# Altium schematic sheet SSD202.SchDoc(SSD202)
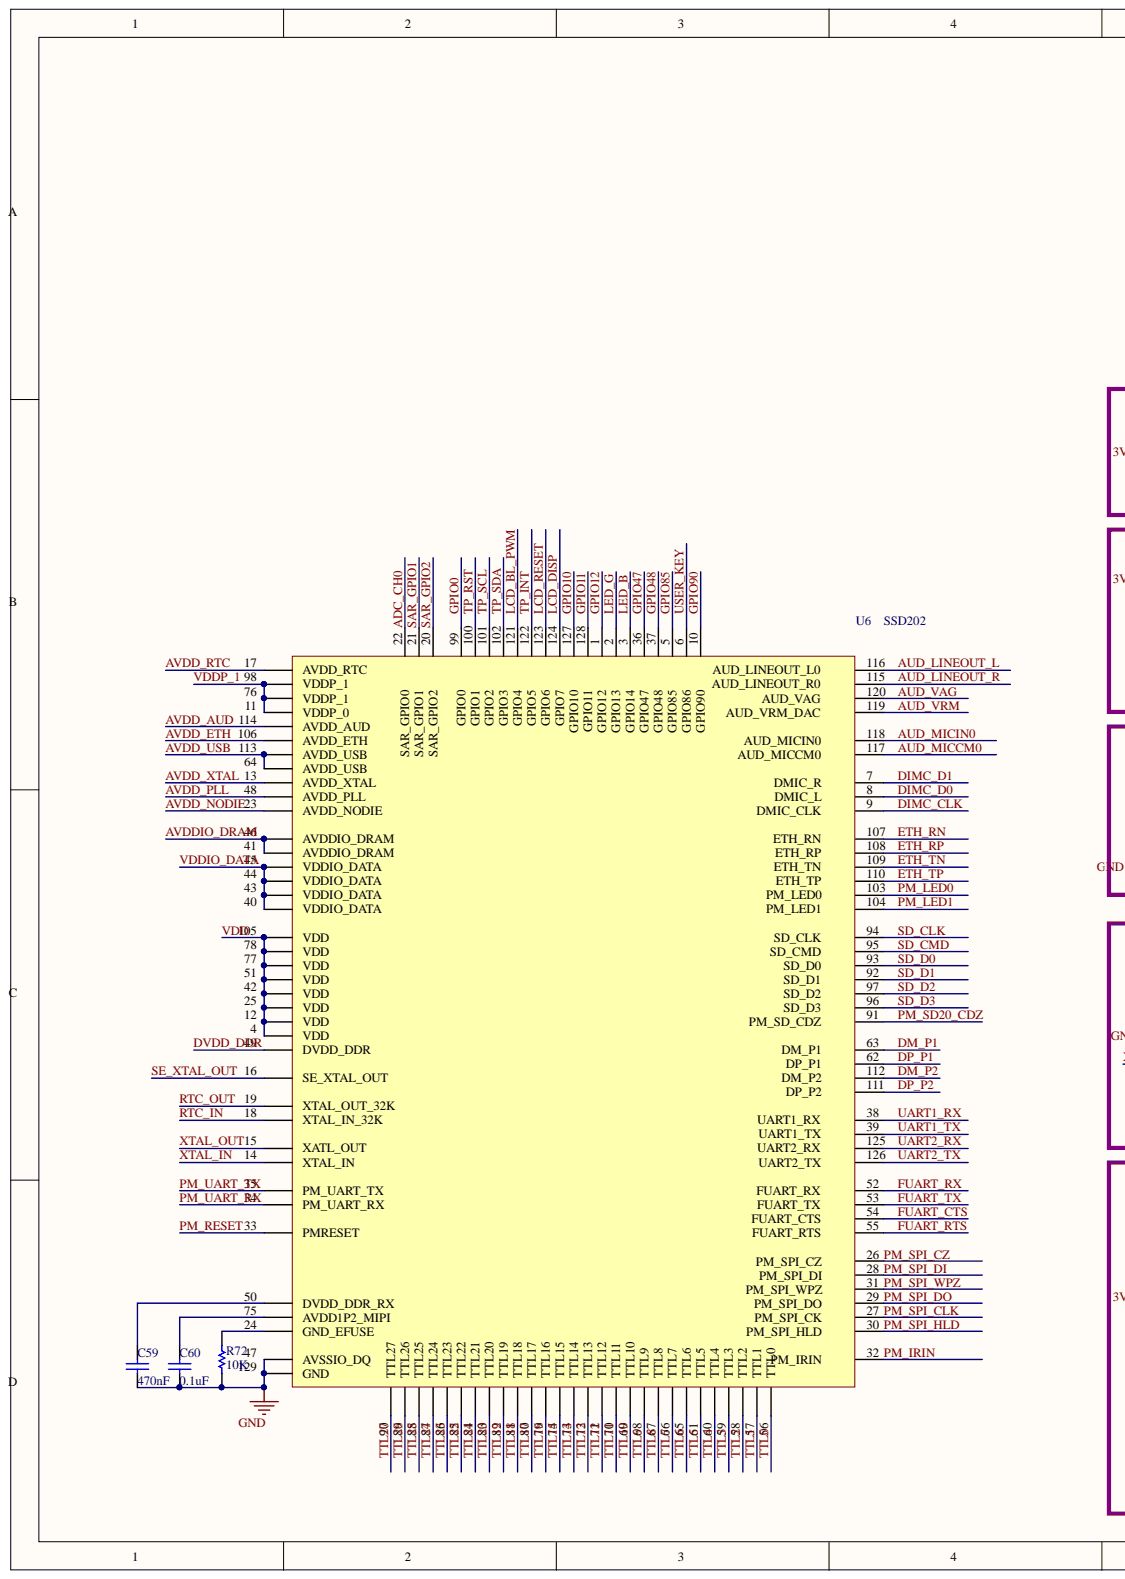
[diagram p0: SSD202.SchDoc, left]
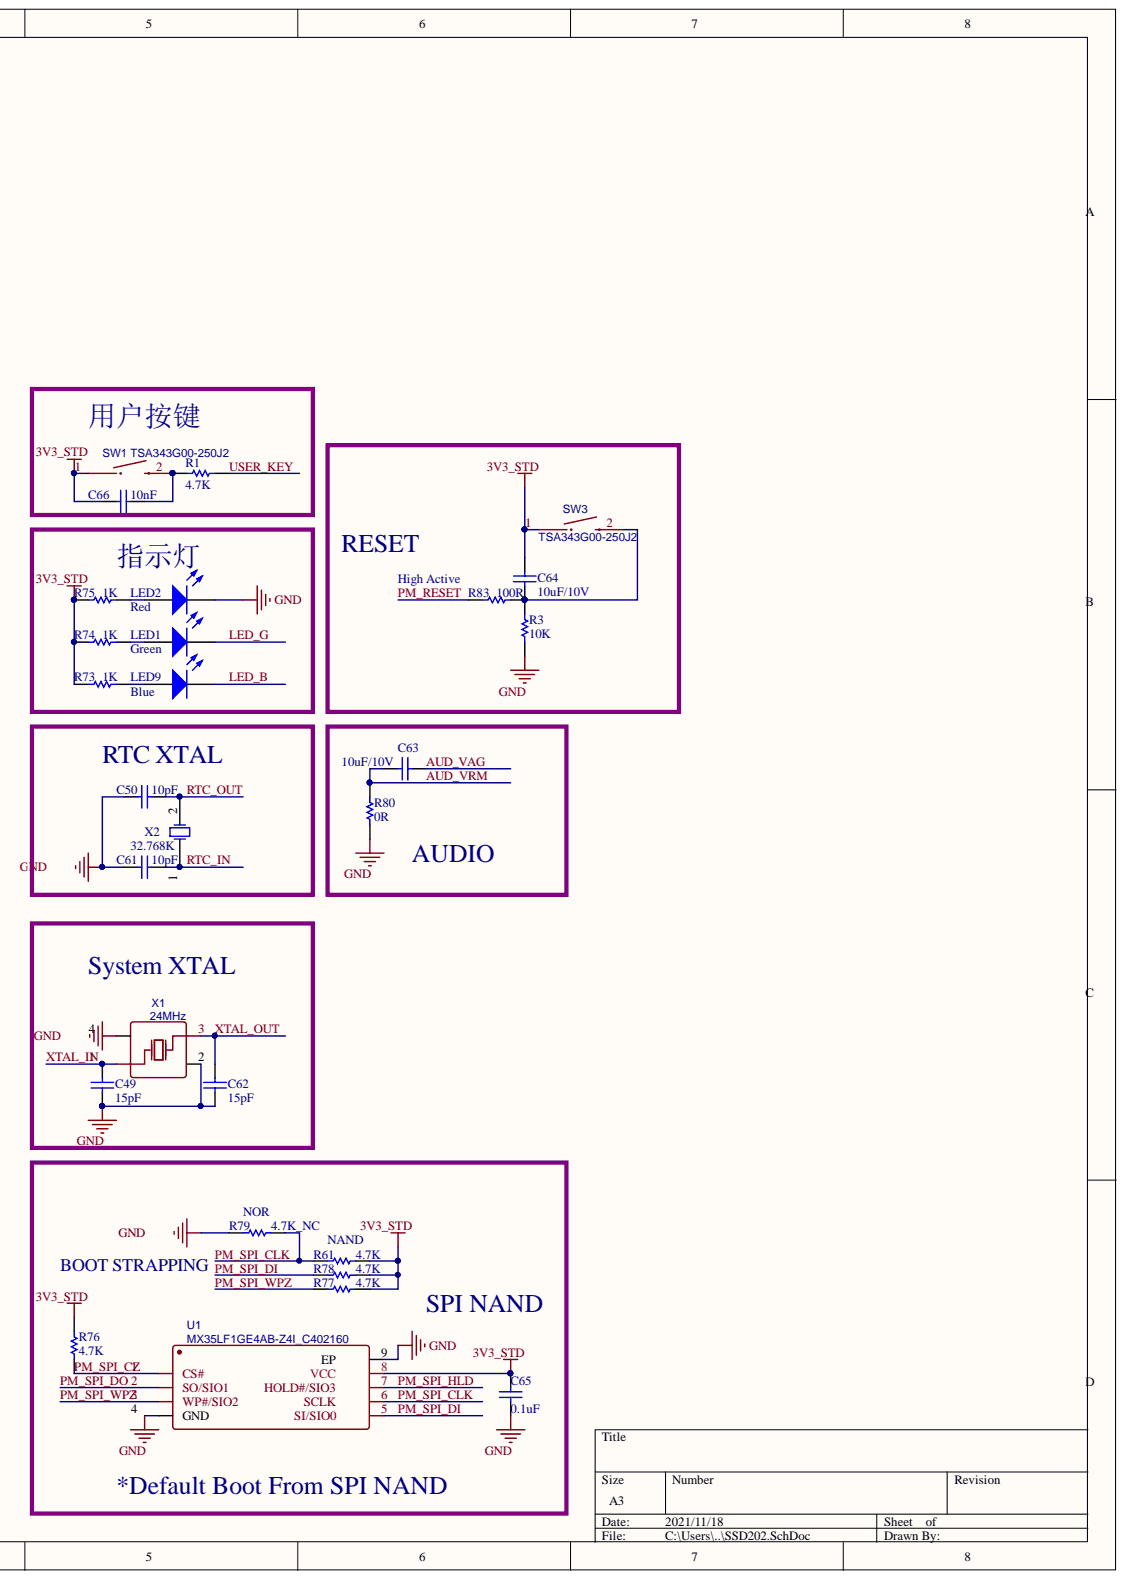
[diagram p1: SSD202.SchDoc, right]

## Parts
C49  15pF  [0402]
C50  10pF  [0402]
C59  470nF  [0402]
C60  0.1uF  [0402]
C61  10pF  [0402]
C62  15pF  [0402]
C63  10uF/10V  [0603]
C64  10uF/10V  [0603]
C65  0.1uF  [0402]
C66  10nF  [0402]
LED1  Green  [LED0603]
LED2  Red  [LED0603]
LED9  Blue  [LED0603]
R1  4.7K  [0402]
R3  10K  [0402]
R61  4.7K  [0402]
R72  10K  [0402]
R73  1K  [0402]
R74  1K  [0402]
R75  1K  [0402]
R76  4.7K  [0402]
R77  4.7K  [0402]
R78  4.7K  [0402]
R79  4.7K_NC  [0402]
R80  0R  [0402]
R83  100R  [0402]
SW1  TSA343G00-250J2  [SW-SMD_L4.0-W2.9-LS5.0]
SW3  TSA343G00-250J2  [SW-SMD_L4.0-W2.9-LS5.0]
U1  MX35LF1GE4AB-Z4I_C402160  [WSON-8_L6.0-W8.0-P1.27-BL-EP]
U6  SSD202  [SSD202_QFN128]
X1  24MHz  [OSC-SMD_4P-L3.2-W2.5-BL]
X2  XTAL  value 32.768K  [SMD3215]

## Nets
3V3_STD: C64.2 C65.2 C66.1 R61.2 R73.2 R74.2 R75.2 R76.1 R77.2 R78.2 SW1.1 SW3.1 U1.8
GND: C49.2 C50.2 C59.2 C60.2 C61.2 C62.2 C65.1 LED2.2 R3.2 R72.2 R79.1 R80.2 U1.4(GND) U1.9 U6.47(AVSSIO_DQ) U6.129(GND) X1.2 X1.4
ADC_CH0: U6.22
AUD_LINEOUT_L: U6.116
AUD_LINEOUT_R: U6.115
AUD_MICCM0: U6.117
AUD_MICIN0: U6.118
AUD_VAG: C63.2 U6.120
AUD_VRM: C63.1 R80.1 U6.119
AVDD_AUD: U6.114(AVDD_AUD)
AVDD_ETH: U6.106(AVDD_ETH)
AVDD_NODIE: U6.23(AVDD_NODIE)
AVDD_PLL: U6.48(AVDD_PLL)
AVDD_RTC: U6.17(AVDD_RTC)
AVDD_USB: U6.64(AVDD_USB) U6.113(AVDD_USB)
AVDD_XTAL: U6.13(AVDD_XTAL)
AVDDIO_DRAM: U6.41(AVDDIO_DRAM) U6.46(AVDDIO_DRAM)
DIMC_CLK: U6.9
DIMC_D0: U6.8
DIMC_D1: U6.7
DM_P1: U6.63
DM_P2: U6.112
DP_P1: U6.62
DP_P2: U6.111
DVDD_DDR: U6.49(DVDD_DDR)
ETH_RN: U6.107
ETH_RP: U6.108
ETH_TN: U6.109
ETH_TP: U6.110
FUART_CTS: U6.54
FUART_RTS: U6.55
FUART_RX: U6.52
FUART_TX: U6.53
GPIO0: U6.99
GPIO10: U6.127
GPIO11: U6.128
GPIO12: U6.1
GPIO47: U6.36
GPIO48: U6.37
GPIO85: U6.5
GPIO90: U6.10
LCD_BL_PWM: U6.121
LCD_DISP: U6.124
LCD_RESET: U6.123
LED_B: LED9.2 U6.3
LED_G: LED1.2 U6.2
PM_IRIN: U6.32
PM_LED0: U6.103
PM_LED1: U6.104
PM_RESET: R83.1 U6.33(PMRESET)
PM_SD20_CDZ: U6.91
PM_SPI_CLK: R61.1 R79.2 U1.6 U6.27
PM_SPI_CZ: R76.2 U1.1(CS#) U6.26
PM_SPI_DI: R78.1 U1.5 U6.28
PM_SPI_DO: U1.2(SO/SIO1) U6.29
PM_SPI_HLD: U1.7 U6.30
PM_SPI_WPZ: R77.1 U1.3(WP#/SIO2) U6.31
PM_UART_RX: U6.34(PM_UART_RX)
PM_UART_TX: U6.35(PM_UART_TX)
RTC_IN: C61.1 U6.18(XTAL_IN_32K) X2.1
RTC_OUT: C50.1 U6.19(XTAL_OUT_32K) X2.2
SAR_GPIO1: U6.21
SAR_GPIO2: U6.20
SD_CLK: U6.94
SD_CMD: U6.95
SD_D0: U6.93
SD_D1: U6.92
SD_D2: U6.97
SD_D3: U6.96
SE_XTAL_OUT: U6.16(SE_XTAL_OUT)
TP_INT: U6.122
TP_RST: U6.100
TP_SCL: U6.101
TP_SDA: U6.102
TTL0: U6.56(TTL0)
TTL1: U6.57(TTL1)
TTL2: U6.58(TTL2)
TTL3: U6.59(TTL3)
TTL4: U6.60(TTL4)
TTL5: U6.61(TTL5)
TTL6: U6.65(TTL6)
TTL7: U6.66(TTL7)
TTL8: U6.67(TTL8)
TTL9: U6.68(TTL9)
TTL10: U6.69(TTL10)
TTL11: U6.70(TTL11)
TTL12: U6.71(TTL12)
TTL13: U6.72(TTL13)
TTL14: U6.73(TTL14)
TTL15: U6.74(TTL15)
TTL16: U6.79(TTL16)
TTL17: U6.80(TTL17)
TTL18: U6.81(TTL18)
TTL19: U6.82(TTL19)
TTL20: U6.83(TTL20)
TTL21: U6.84(TTL21)
TTL22: U6.85(TTL22)
TTL23: U6.86(TTL23)
TTL24: U6.87(TTL24)
TTL25: U6.88(TTL25)
TTL26: U6.89(TTL26)
TTL27: U6.90(TTL27)
UART1_RX: U6.38
UART1_TX: U6.39
UART2_RX: U6.125
UART2_TX: U6.126
USER_KEY: R1.2 U6.6
VDD: U6.4(VDD) U6.12(VDD) U6.25(VDD) U6.42(VDD) U6.51(VDD) U6.77(VDD) U6.78(VDD) U6.105(VDD)
VDDIO_DATA: U6.40(VDDIO_DATA) U6.43(VDDIO_DATA) U6.44(VDDIO_DATA) U6.45(VDDIO_DATA)
VDDP_1: U6.11(VDDP_0) U6.76(VDDP_1) U6.98(VDDP_1)
XTAL_IN: C49.1 U6.14(XTAL_IN) X1.1
XTAL_OUT: C62.1 U6.15(XATL_OUT) X1.3
NetC59_1: C59.1 U6.50(DVDD_DDR_RX)
NetC60_1: C60.1 U6.75(AVDD1P2_MIPI)
NetC64_1: C64.1 R3.1 R83.2 SW3.2
NetC66_2: C66.2 R1.1 SW1.2
NetLED1_1: LED1.1 R74.1
NetLED2_1: LED2.1 R75.1
NetLED9_1: LED9.1 R73.1
NetR72_1: R72.1 U6.24(GND_EFUSE)

## Library data of the parts
C49 C50 C61 C62 C66 = Cap: Capacitor; PartNumber: CAP18PF; Component Kind: Standard; LatestRevisionDate: 17-Jul-2002; LatestRevisionNote: Re-released for DXP Platform.; Library Name: Miscellaneous Devices.IntLib; PackageReference: 0402; Published: 8-Jun-2000; Signal Integrity: Cap; Simulation: CAP; Publisher: Altium Limited
  C49 C62: Value: 15pF
  C50 C61: Value: 10pF
  C66: Value: 10nF
C59 C60 C63-C65 = Cap: Capacitor; PartNumber: CAP100NF; Component Kind: Standard; LatestRevisionDate: 17-Jul-2002; LatestRevisionNote: Re-released for DXP Platform.; Library Name: Miscellaneous Devices.IntLib; PackageReference: 0402; Published: 8-Jun-2000; Signal Integrity: Cap; Simulation: CAP; Publisher: Altium Limited
  C59: Value: 470nF
  C60 C65: Value: 0.1uF
  C63 C64: Value: 10uF/10V
LED1 LED2 LED9 = LED2: Typical RED, GREEN, YELLOW, AMBER GaAs LED; Component Kind: Standard; LatestRevisionDate: 17-Jul-2002; LatestRevisionNote: Re-released for DXP Platform.; Library Name: Miscellaneous Devices.IntLib; PackageDescription: SM LED; 2 Flat Leads; PackageReference: 3.2X1.6X1.1; PackageVersion: Feb-2002; Published: 8-Jun-2000; Simulation: LED2; Publisher: Altium Limited
  LED1: Value: Green
  LED2: Value: Red
  LED9: Value: Blue
R1 R3 R61 R72-R80 R83 = Res1: Resistor; PartNumber: RES2.2K; Component Kind: Standard; LatestRevisionDate: 17-Jul-2002; LatestRevisionNote: Re-released for DXP Platform.; Library Name: Miscellaneous Devices.IntLib; PackageReference: 0402; Published: 8-Jun-2000; Signal Integrity: Res; Simulation: RESISTOR; Publisher: Altium Limited
  R1 R61 R76-R78: Value: 4.7K
  R3 R72: Value: 10K
  R73-R75: Value: 1K
  R79: Value: 4.7K_NC
  R80: Value: 0R
  R83: Value: 100R
SW1 SW3 = TSA343G00-250J2: BOM_Manufacturer: BRIGHT; Contributor: LCSC; BOM_JLCPCB Part Class: Extended Part; BOM_Manufacturer Part: TSA343G00-250J2; BOM_Supplier: LCSC; BOM_Supplier Part: C354946; Component Kind: Standard; spicePre: S; spiceSymbolName: TSA343G00-250J2
U1 = MX35LF1GE4AB-Z4I_C402160: BOM_Manufacturer: Macronix; Contributor: LCSC; BOM_JLCPCB Part Class: Extended Part; BOM_Manufacturer Part: MX35LF1GE4AB-Z4I; BOM_Supplier: LCSC; BOM_Supplier Part: C402160; Component Kind: Standard; spicePre: U; spiceSymbolName: MX35LF1GE4AB-Z4I_C402160
X1 = 24MHz: BOM_Manufacturer: JGHC; BOM_Manufacturer Part: S3224000151050; Contributor: LCSC; nameAlias: Frequency; BOM_Supplier: LCSC; BOM_Supplier Part: C426976; Component Kind: Standard; spicePre: X; spiceSymbolName: S3224000151050
X2 = XTAL: Crystal Oscillator; Component Kind: Standard; LatestRevisionDate: 17-Jul-2002; LatestRevisionNote: Re-released for DXP Platform.; Library Name: Miscellaneous Devices.IntLib; PackageDescription: Crystal, Thru-Hole; 2 Leads; Body 3.1 x 8.2 mm (Dia.xH); PackageReference: R38; Published: 8-Jun-2000; Publisher: Altium Limited
U6 = SSD202: Component Kind: Standard; Library Name: HM2.SchLib
